# Altium schematic sheet stm32_board.SchDoc(stm32_board)
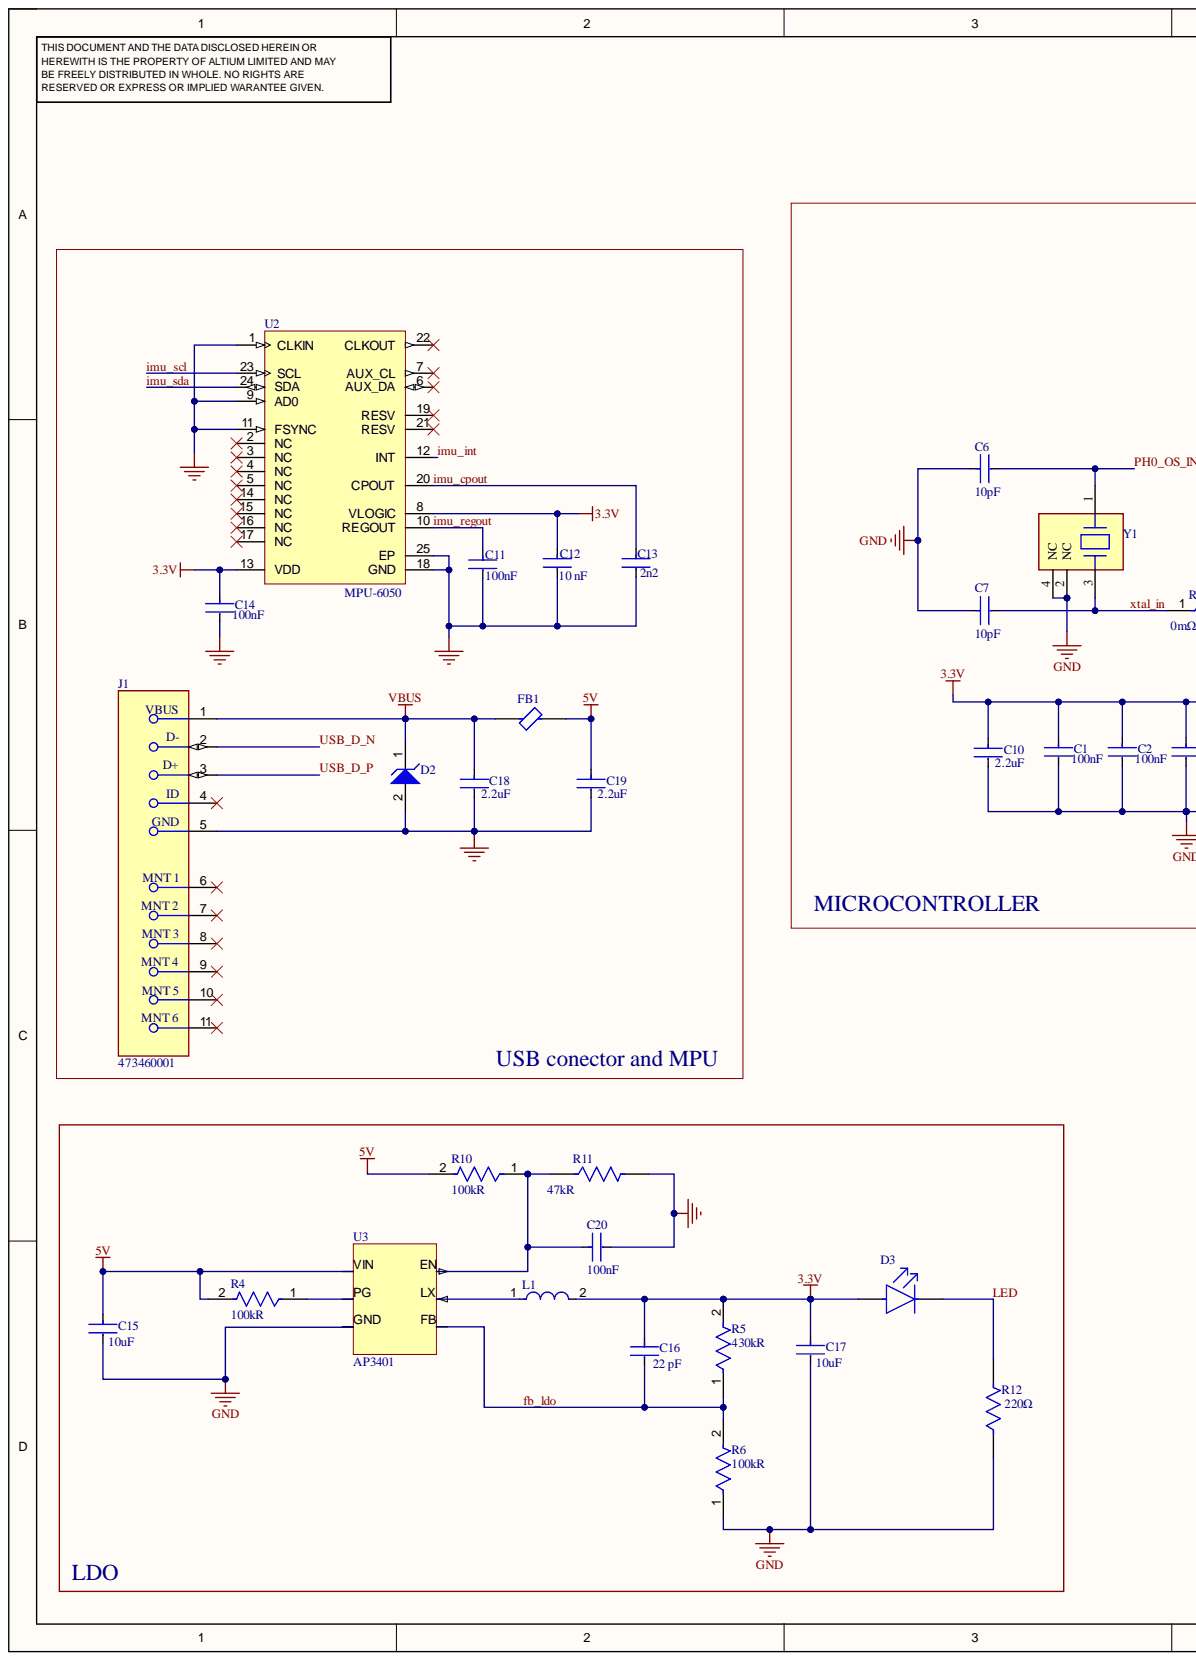
[diagram p0: stm32_board.SchDoc, left]
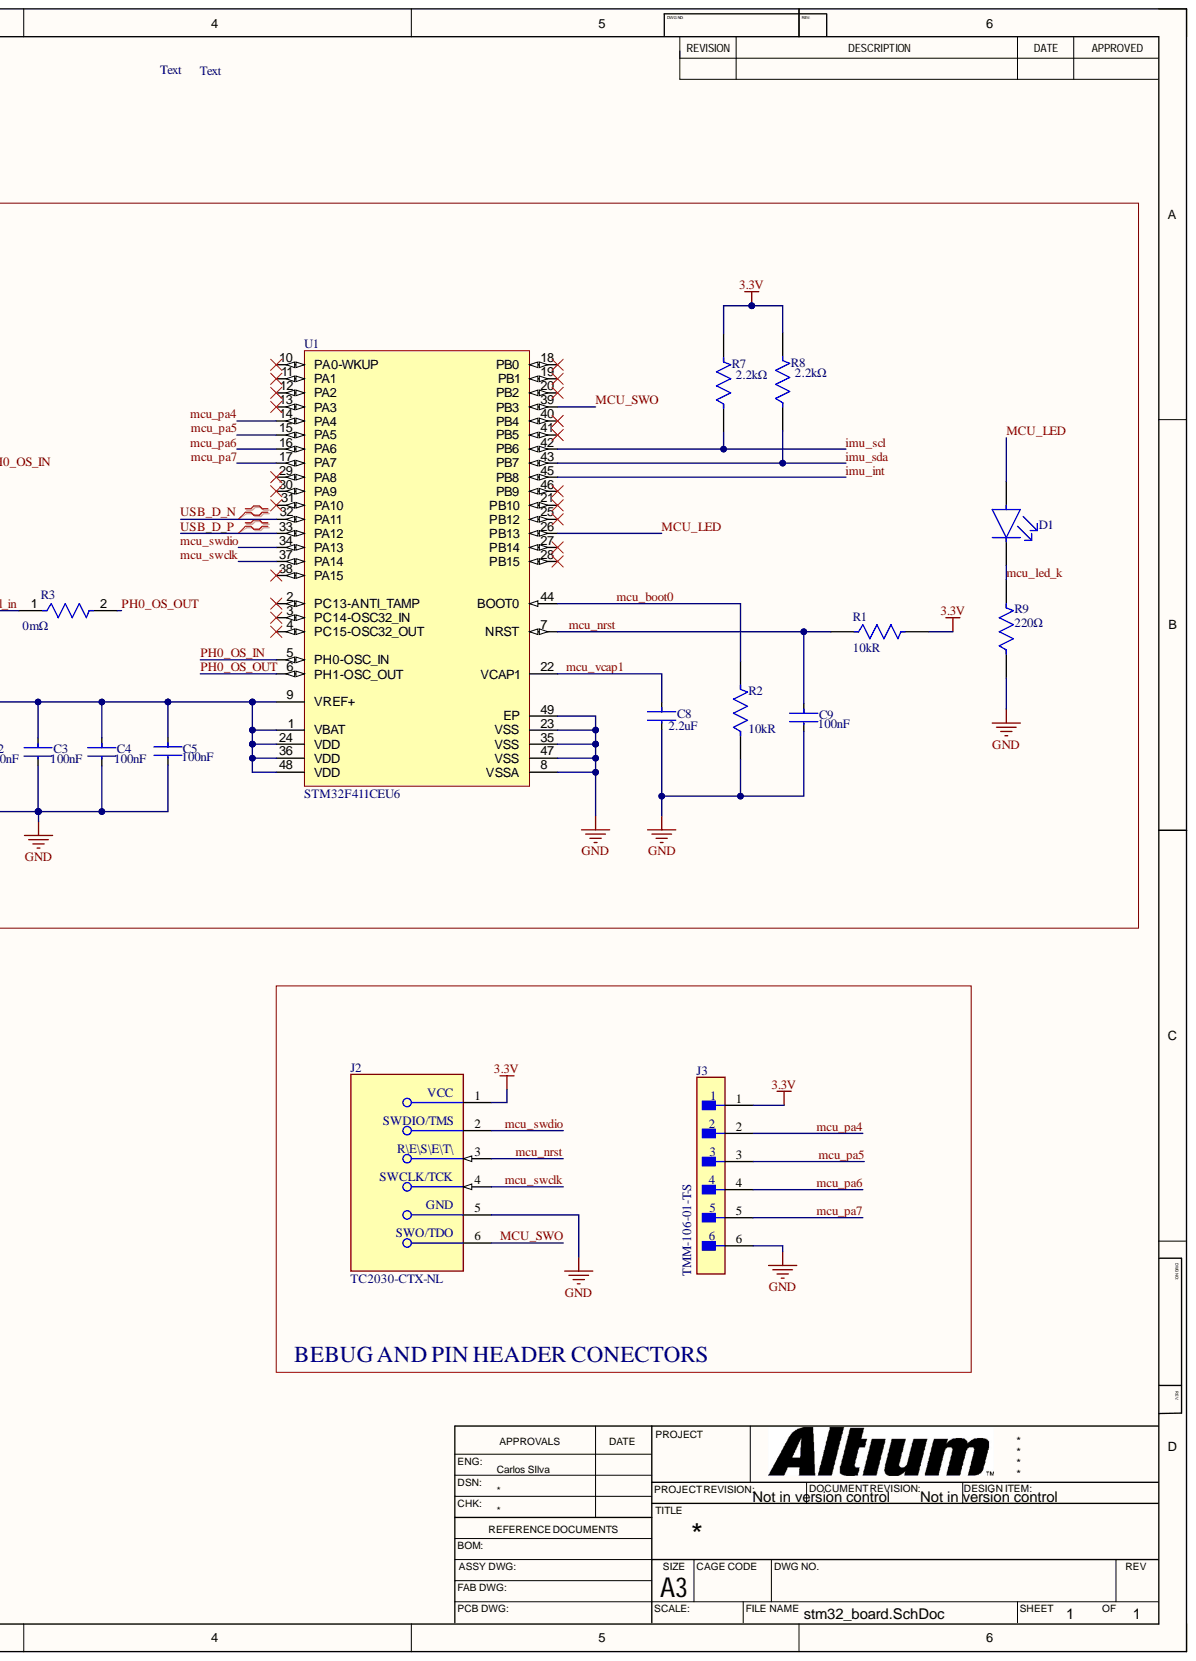
[diagram p1: stm32_board.SchDoc, right]

## Parts
C1  Capacitance: 100nF  [FP-CL17-IPC_C]  (hidden comment: CL05B104KP5NNNC)
C2  Capacitance: 100nF  [FP-CL17-IPC_C]  (hidden comment: CL05B104KP5NNNC)
C3  Capacitance: 100nF  [FP-CL17-IPC_C]  (hidden comment: CL05B104KP5NNNC)
C4  Capacitance: 100nF  [FP-CL17-IPC_C]  (hidden comment: CL05B104KP5NNNC)
C5  Capacitance: 100nF  [FP-CL17-IPC_C]  (hidden comment: CL05B104KP5NNNC)
C6  Capacitance: 10pF  [FP-GRM022-0_02-IPC_C]  (hidden comment: GRM0225C1C100JA03L)
C7  Capacitance: 10pF  [FP-GRM022-0_02-IPC_C]  (hidden comment: GRM0225C1C100JA03L)
C8  Capacitance: 2.2uF  [FP-GRM155-0_2-0_05-IPC_A]  (hidden comment: GRM155D71A225ME15D)
C9  Capacitance: 100nF  [FP-CL17-IPC_C]  (hidden comment: CL05B104KP5NNNC)
C10  Capacitance: 2.2uF  [FP-GRM155-0_2-0_05-IPC_A]  (hidden comment: GRM155D71A225ME15D)
C11  Capacitance: 100nF  [FP-CL17-IPC_C]  (hidden comment: CL05B104KP5NNNC)
C12  Capacitance: 10 nF  [FP-C0603C-CF-MFG]  (hidden comment: C0603C103K5RACTU)
C13  value 2n2  [FP-C0402-BB-MFG]  (hidden comment: C0402C222F4GACTU)
C14  Capacitance: 100nF  [FP-CL17-IPC_C]  (hidden comment: CL05B104KP5NNNC)
C15  Capacitance: 10uF  [FP-0603-L_1_6_0_2-W_0_8_0-IPC_A]  (hidden comment: LMK107BBJ106MALT)
C16  value 22 pF  Capacitance: 22 pF  [FP-C0603C-CF-MFG]  (hidden comment: C0603C220J5GACTU)
C17  Capacitance: 10uF  [FP-0603-L_1_6_0_2-W_0_8_0-IPC_A]  (hidden comment: LMK107BBJ106MALT)
C18  Capacitance: 2.2uF  [FP-GRM155-0_2-0_05-IPC_A]  (hidden comment: GRM155D71A225ME15D)
C19  Capacitance: 2.2uF  [FP-GRM155-0_2-0_05-IPC_A]  (hidden comment: GRM155D71A225ME15D)
C20  Capacitance: 100nF  [FP-CL17-IPC_C]  (hidden comment: CL05B104KP5NNNC)
D1  LTST-C190GKT  [FP-LTST-C190GKT-MFG]  (value not drawn)
D2  ESD9X5.0ST5G  [ONSC-SOD-923-2-514AA-01_V]  (value not drawn)
D3  LTST-C190GKT  [FP-LTST-C190GKT-MFG]  (value not drawn)
FB1  BLM18KG121TN1D  [FP-BLM18-0_15-t0_6-d1_2-IPC_A]  (value not drawn)
J1  473460001  [FP-473460001-MFG]
J2  TC2030-CTX-NL  [FP-TC2030-CTX-NL-MFG]
J3  TMM-106-01-T-S  [FP-TMM-106-01-T-S-MFG]
L1  LQM2MPN2R2NG0L  [FP-LQM2MPN_G0-MFG]  (value not drawn)
R1  Resistance: 10kR  [FP-RMCF0603-MFG]  (hidden comment: RMCF0603FT10K0)
R2  Resistance: 10kR  [FP-RMCF0603-MFG]  (hidden comment: RMCF0603FT10K0)
R3  value 0mΩ  Resistance: 0mΩ  [FP-RMCF0603-MFG]  (hidden comment: RMCF0603ZT0R00)
R4  Resistance: 100kR  [FP-RMCF0603-IPC_C]  (hidden comment: RMCF0603FT100K)
R5  Resistance: 430kR  [FP-KTR25-MFG]  (hidden comment: KTR25JZPF4303)
R6  Resistance: 100kR  [FP-RMCF0603-IPC_C]  (hidden comment: RMCF0603FT100K)
R7  value 2.2kΩ  Resistance: 2.2kΩ  [FP-ERA2A-MFG]  (hidden comment: ERA-2AEB222X)
R8  value 2.2kΩ  Resistance: 2.2kΩ  [FP-ERA2A-MFG]  (hidden comment: ERA-2AEB222X)
R9  value 220Ω  Resistance: 220Ω  [FP-CRGCQ0402-IPC_C]  (hidden comment: CRGCQ0402J220R)
R10  Resistance: 100kR  [FP-RMCF0603-IPC_C]  (hidden comment: RMCF0603FT100K)
R11  Resistance: 47kR  [FP-RC0603FR-0747KL-MFG]  (hidden comment: RC0603FR-0747KL)
R12  value 220Ω  Resistance: 220Ω  [FP-CRGCQ0402-IPC_C]  (hidden comment: CRGCQ0402J220R)
U1  STM32F411CEU6  [STM-UFQFPN48_L]
U2  MPU-6050  [IS-QFN-24_V]
U3  AP3401  [AP3401]
Y1  XRCGB24M000F3M00R0  [FP-XRCGB_F_N-MFG]  (value not drawn)

## Nets
3.3V: C1.1 C2.1 C3.1 C4.1 C5.1 C10.1 C12.1 C14.1 C16.1 C17.1 D3.2 J2.1 J3.1 L1.2 R1.2 R5.2 R7.2 R8.2 U1.1(VBAT) U1.9(VREF+) U1.24(VDD) U1.36(VDD) U1.48(VDD) U2.8(VLOGIC) U2.13(VDD)
5V: C15.1 C19.1 FB1.2 R4.2 R10.2 U3.VIN
GND: C1.2 C2.2 C3.2 C4.2 C5.2 C6.1 C7.1 C8.2 C9.2 C10.2 C11.2 C12.2 C13.2 C14.2 C15.2 C17.2 C18.2 C19.2 C20.2 D2.2 J1.5 J2.5 J3.6 R2.1 R6.1 R9.1 R11.2 R12.1 U1.8(VSSA) U1.23(VSS) U1.35(VSS) U1.47(VSS) U1.49(EP) U2.1(CLKIN) U2.9(AD0) U2.11(FSYNC) U2.18(GND) U2.25(EP) U3.GND Y1.2(NC) Y1.4(NC)
VBUS: C18.1 D2.1 FB1.1 J1.1
fb_ldo: C16.2 R5.1 R6.2 U3.FB
imu_cpout: C13.1 U2.20(CPOUT)
imu_int: U1.45(PB8) U2.12(INT)
imu_regout: C11.1 U2.10(REGOUT)
imu_scl: R7.1 U1.42(PB6) U2.23(SCL)
imu_sda: R8.1 U1.43(PB7) U2.24(SDA)
LED: D3.1 R12.2
mcu_boot0: R2.2 U1.44(BOOT0)
MCU_LED: D1.2 U1.26(PB13)
mcu_led_k: D1.1 R9.2
mcu_nrst: C9.1 J2.3 R1.1 U1.7(NRST)
mcu_pa4: J3.2 U1.14(PA4)
mcu_pa5: J3.3 U1.15(PA5)
mcu_pa6: J3.4 U1.16(PA6)
mcu_pa7: J3.5 U1.17(PA7)
mcu_swclk: J2.4 U1.37(PA14)
mcu_swdio: J2.2 U1.34(PA13)
MCU_SWO: J2.6 U1.39(PB3)
mcu_vcap1: C8.1 U1.22(VCAP1)
PH0_OS_IN: C6.2 U1.5(PH0-OSC_IN) Y1.1
PH0_OS_OUT: R3.2 U1.6(PH1-OSC_OUT)
USB_D_N: J1.2 U1.32(PA11)
USB_D_P: J1.3 U1.33(PA12)
xtal_in: C7.2 R3.1 Y1.3
NetC20_1: C20.1 R10.1 R11.1 U3.EN
NetL1_1: L1.1 U3.LX
NetR4_1: R4.1 U3.PG

Not connected: J1 4 6 7 8 9 10 11; U1 2(PC13-ANTI_TAMP) 3(PC14-OSC32_IN) 4(PC15-OSC32_OUT) 10(PA0-WKUP) 11(PA1) 12(PA2) 13(PA3) 18(PB0) 19(PB1) 20(PB2) 21(PB10) 25(PB12) 27(PB14) 28(PB15) 29(PA8) 30(PA9) 31(PA10) 38(PA15) 40(PB4) 41(PB5) 46(PB9); U2 2(NC) 3(NC) 4(NC) 5(NC) 6(AUX_DA) 7(AUX_CL) 14(NC) 15(NC) 16(NC) 17(NC) 19(RESV) 21(RESV) 22(CLKOUT)

## Library data of the parts
C1-C5 C9 C11 C14 C20 = CMP-13271-001069-1: Cap Ceramic 100nF 10V X7R ±10% SMD 0402 +125°C Paper T/R; Case Code (Imperial): 0402; Case Code (Metric): 1005; Case/Package: 0402; Component Kind: Standard; Contact Plating: Tin; Depth: 0.5mm; Dielectric: X7R; Height: 0.5mm; Length: 1mm; Library Name: Altium Content Vault; Max Operating Temperature: 125°C; Min Operating Temperature: -55°C; Mount: Surface Mount; Package Quantity: 1; Packaging: Tape and Reel; Radiation Hardening: No; Thickness: 0.022inch; Tolerance: 10%; Voltage Rating: 10V; Width: 0.02inch
C6 C7 = CMP-06035-032745-1: Chip Multilayer Ceramic Capacitors for General Purpose, 01005, 10pF, C0G, 30ppm/°C, 5%, 16V; Case Code (Imperial): 1005; Case Code (Metric): 0402; Component Kind: Standard; Dielectric: C0G; Length: 0.016inch; Library Name: Altium Content Vault; Max Operating Temperature: 125°C; Min Operating Temperature: -55°C; Mount: Surface Mount; Packaging: Cut Tape; Thickness: 0.009inch; Tolerance: 5%; Voltage Rating: 16V; Voltage Rating (DC): 16V; Width: 0.008inch
C8 C10 C18 C19 = CMP-06035-053899-1: Chip Multilayer Ceramic Capacitors for General Purpose, 0402, 2.2uF, X7T, +22%/-33%, 20%, 10V; Case Code (Imperial): 0402; Case Code (Metric): 1005; Case/Package: 0402; Component Kind: Standard; Dielectric: X7T; Height: 0.5mm; Length: 0.039inch; Library Name: Altium Content Vault; Max Operating Temperature: 125°C; Min Operating Temperature: -55°C; Mount: Surface Mount; Package Quantity: 10000; Packaging: Tape and Reel; Termination: SMD/SMT; Thickness: 0.022inch; Tolerance: 20%; Voltage Rating: 10V; Voltage Rating (DC): 10V; Weight: 0.000212oz; Width: 0.02inch
C12 = CMP-2006-03046-3: CAP CER 10000PF 50V X7R 0603; Manufacturer: KEMET; Automotive Grade: Grade 1; Material: Ceramic; Temperature Coefficient: 30ppm/°C; Tolerance: 0.1; Value: 10,000 pF; Automotive: Yes; Category: Cap; Component Kind: Standard; ComponentLink2Description: Datasheet; ComponentLink2URL: https://api.kemet.com/component-edge/download/datasheet/C0603C100J5GACAUTO.pdf; Device Class L1: Passive Components; Device Class L2: Capacitors; Device Class L3: Ceramic Capacitors; Digikey Description: CAP CER 10000PF 50V X7R 0603; Height: 0.87mm; Lead Free: Yes; Library Name: Altium Content Vault; Manufacturer Part Number: C0603C103K5RACTU; Mouser Description: Ceramic Capacitor 0.01Uf, 50V, X7R, 10%, 0603, Full Reel; Octopart: https://octopart.com/c0603c103k5ractu-kemet-133094; Package: C0603C-CF; RoHS: TRUE; Temperature: -55°C to +125°C; Temperature Characteristic: X7R; Temperature Range High: +125°C; Temperature Range Low: -55°C; Voltage Rating: 50 V
C13 = CMP-1034-01730-4: CAP CER 2200PF 16V NP0 0402; Manufacturer: Kemet; Capacitance: 2,200 pF; Material: Ceramic; Temperature Characteristic: C0G; Temperature Coefficient: 15%; Tolerance: 0.01; Automotive: No; Category: Cap; Component Kind: Standard; ComponentLink2Description: Datasheet; ComponentLink2URL: https://content.kemet.com/datasheets/KEM_C1002_X7R_SMD.pdf; Device Class L1: Passive Components; Device Class L2: Capacitors; Device Class L3: Ceramic Capacitors; Digikey Description: CAP CER 2200PF 16V NP0 0402; Height: 0.55mm; Ipc Land Pattern Name: CAPC10050X50; Lead Free: Yes; Library Name: Altium Content Vault; Manufacturer Part Number: C0402C222F4GACTU; Mouser Description: Cap Ceramic 0.0022uF 16V C0G 1% SMD 0402 125°C Punched Paper T/R; Octopart: https://octopart.com/c0402c222f4gactu-kemet-12823119; Package: C0402-BB; RoHS: TRUE; Temperature: -55°C to +125°C; Temperature Range High: +125°C; Temperature Range Low: -55°C; Voltage Rating: 16 V
C15 C17 = CMP-14477-000150-3: Multilayer Ceramic Capacitor 10uF 10V X5R 20% SMD 0603 T/R; Case Code (Imperial): 0603; Case Code (Metric): 1608; Case/Package: 0603; Component Kind: Standard; Construction: Flat; Depth: 0.8mm; Dielectric: X5R; Height: 0.8mm; Lead Free: Lead Free; Length: 1.6mm; Library Name: Altium Content Vault; Max Operating Temperature: 85°C; Min Operating Temperature: -55°C; Mount: Surface Mount; Package Quantity: 1; Packaging: Tape and Reel; Radiation Hardening: No; Resistor Type: Ceramic; RoHS Compliant: Yes; Series: M; Termination: SMD/SMT; Thickness: 0.035inch; Tolerance: 20%; Voltage: 10V; Voltage Rating: 10V; Voltage Rating (DC): 10V; Width: 0.8mm
C16 = CMP-2006-03119-3: Cap Ceramic 22pF 50V C0G 5% SMD 0603 125°C Paper T/R; Manufacturer: KEMET; Automotive Grade: Grade 1; Temperature Coefficient: 30ppm/°C; Tolerance: 0.05; Automotive: Yes; Category: Cap; Component Kind: Standard; ComponentLink2Description: Datasheet; ComponentLink2URL: https://api.kemet.com/component-edge/download/datasheet/C0603C100J5GACAUTO.pdf; Device Class L1: Passive Components; Device Class L2: Capacitors; Device Class L3: Ceramic Capacitors; Digikey Description: Cap Ceramic 22pF 50V C0G 5% SMD 0603 125°C Paper T/R; Height: 0.87mm; Lead Free: Yes; Library Name: Altium Content Vault; Manufacturer Part Number: C0603C220J5GACTU; Material: Ceramic; Mouser Description: Cap Ceramic 22pF 50V C0G 5% SMD 0603 125°C Paper T/R; Octopart: https://octopart.com/c0603c220j5gactu-kemet-98525; Package: C0603C-CF; RoHS: TRUE; Temperature: -55°C to +125°C; Temperature Characteristic: C0G; Temperature Range High: +125°C; Temperature Range Low: -55°C; Voltage Rating: 50 V
D1 D3 = CMP-22017-000005-2: LED GREEN CLEAR CHIP SMD; Dominant Wavelength: 569nm; Forward Current: 30mA; Forward Voltage: 2.1V; Lens Color: Colorless; Luminous Intensity: 6mcd; Number of Elements: 1; Power Dissipation: 100mW; Reverse Voltage: 5V; Test Current: 10mA; Viewing Angle: 130°; Voltage: 2V; Wavelength: 565nm; Case Code (Imperial): 0603; Case Code (Metric): 1608; Case/Package: 0603; Color: Green; Component Kind: Standard; Depth: 0.8mm; Height: 0.8mm; Illumination Color: Green; Lead Free: Lead Free; Length: 1.6mm; Lens Style: Clear; Lens Transparency: Clear; Library Name: Altium Content Vault; Max Operating Temperature: 85°C; Min Operating Temperature: -55°C; Mount: Surface Mount; Number of LEDs: 1; Number of Pins: 2; Package Quantity: 1; Packaging: Tape and Reel; Power Rating: 100mW; Radiation Hardening: No; Width: 0.8mm
D2 = CMP-1063-00108-1: Transient Voltage Suppressor, Micro-Packaged Diode for ESD Protection, 2-Pin SOD-923, Pb-Free, Tape and Reel; Manufacturer: On Semiconductor; Direction: Unidirectional; IR Max (uA): 1; V(BR) Min (V): 6.2; VRWM Max (V): 5; Component Kind: Standard; ComponentLink1Description: Manufacturer URL; ComponentLink1URL: http://www.onsemi.com/; ComponentLink2Description: Datasheet; ComponentLink2URL: http://www.onsemi.cn/pub_link/Collateral/ESD9X3.3ST5G-D.PDF; ComponentLink3Description: Package Specification; ComponentLink3URL: http://www.onsemi.com/pub_link/Collateral/514AA.PDF; DatasheetVersion: Rev. 7; Library Name: Altium Content Vault; Mounting Technology: Surface Mount; Number of Lines: 1; PackageDescription: 2-Pin SOD, Body 0.8 x 0.6 mm; PackageReference: SOD-923-2-514AA-01; PackageVersion: Rev. E, 03/2011; Packing: Tape and Reel; PartNumber: ESD9X5.0ST5G; RoHS: Pb-Free
FB1 = CMP-0686-00554-8: FERRITE BEAD 120 OHM 0603 1LN; Current Rating: 3A; DC Resistance (DCR): 30mR; Impedance: 120R; Number of Circuits: 1; Test Frequency: 100MHz; Tolerance: 25%; Case Code (Imperial): 0603; Case Code (Metric): 1608; Case/Package: 0603; Component Kind: Standard; Core Material: Ferrite; Depth: 0.95mm; Height: 0.8mm; Inductor Type: FERRITE BEAD; Lead Free: Lead Free; Length: 1.6mm; Library Name: Altium Content Vault; Material: Ferrite; Max Current Rating: 3A; Max DC Current: 3A; Max Operating Temperature: 125°C; Min Operating Temperature: -55°C; Number of Lines: 1; Number of Pins: 2; Package Quantity: 4000; Packaging: Tape and Reel; REACH SVHC: No SVHC; RoHS Compliant: Yes; Series: BLM18K; Termination: SMD/SMT; Width: 0.8mm
J1 = CMP-2000-05246-2: CONN RCPT USB2.0 MICRO B SMD R/A; Manufacturer: Molex; Conn Orientation: Right Angle; Current Rating: 1.8A; Is Female: yes; Number Of Contacts: 5; Pitch: 0.65mm; Shielding: true; Voltage Rating: 30V; Automotive: No; Category: Conn; Component Kind: Standard; ComponentLink2Description: Datasheet; ComponentLink2URL: https://www.molex.com/webdocs/datasheets/pdf/en-us/0473460001_IO_CONNECTORS.pdf; Contact Material: Gold,Nickel; Device Class L1: Connectors; Device Class L2: USB Connectors; Device Class L3: unset; Digikey Description: CONN RCPT USB2.0 MICRO B SMD R/A; Footprint Url: https://www.molex.com/pdm_docs/sd/473460001_sd.pdf; Height: 2.945mm; Lead Free: Yes; Library Name: Altium Content Vault; Manufacturer Part Number: 473460001; Octopart: https://octopart.com/47346-0001-molex-7164928; Package: 0; RoHS: TRUE; Temperature: -30°C to +85°C; Temperature Range High: +85°C; Temperature Range Low: -30°C; Usb Standard: USB 2.0
J2 = CMP-205963-000001-1: 6-Pin “No legs” TC2030 Plug-of-Nails™ Cable for use with ARM Cortex processors; Component Kind: Standard; Library Name: Altium Content Vault
J3 = CMP-02766-001694-1: CONN HEADER VERT 6POS 2MM; Connector Type: Male Pin; Gender: Male; Max Voltage Rating (AC): 500V; Number of Contacts: 6; Color: Black; Component Kind: Standard; Contact Material: Bronze; Contact Mating Length: 0.126inch; Contact Plating: Tin; Contact Type: Male Pin; Depth: 1.97mm; Housing Color: Black; Housing Material: Polymer; Lead Free: Lead Free; Length: 12mm; Library Name: Altium Content Vault; Max Operating Temperature: 105°C; Min Operating Temperature: -55°C; Mount: Through Hole; Number of Positions: 6; Number of Rows: 1; Orientation: Straight; Packaging: Bulk; Pitch: 2mm; Radiation Hardening: No; REACH SVHC: No SVHC; RoHS Compliant: Yes; Series: FleXYZ™ TMM; Termination: Solder; Width: 1.97mm
L1 = CMP-06042-016770-1: Multilayer Type Inductor for Power Lines, 0806 (2016), 2.2μH, 30%, 0.138Ω, 1.2A; Core Material: Ferrite; DC Resistance (DCR): 138mR; Inductance: 2.2uH; Self Resonant Frequency: 40MHz; Shielding: Shielded; Test Frequency: 1MHz; Case Code (Imperial): 0806; Case Code (Metric): 2016; Case/Package: 0806; Component Kind: Standard; Current Rating: 1.2A; DC Current: 1.2A; Depth: 1.75mm; Height: 1mm; Height - Seated (Max): 0.039inch; Inductance Tolerance: 30%; Lead Free: Lead Free; Length: 0.079inch; Library Name: Altium Content Vault; Max DC Current: 1.2A; Max Operating Temperature: 125°C; Military Standard: Not; Min Operating Temperature: -55°C; Mount: Surface Mount; Package Quantity: 1; Packaging: Tape and Reel; Radiation Hardening: No; REACH SVHC: No SVHC; RoHS Compliant: Yes; Series: LQM2MPN_G0; Termination: SMD/SMT; Tolerance: 30%; Width: 0.063inch
R1 R2 = CMP-2000-06990-2: 10kΩ ±1% 0.1W 0603 Thick Film Chip Resistor AEC-Q200 compliant; Max Power Dissipation: 100mW; Temperature Coefficient: 100ppm/°C; Case Code (Imperial): 0603; Case Code (Metric): 1608; Case/Package: 0603; Component Kind: Standard; Construction: Rectangular; Contact Plating: Tin; Depth: 0.8mm; Features: Automotive AEC-Q200; Height: 0.022inch; Lead Free: Lead Free; Length: 1.55mm; Library Name: Altium Content Vault; Max Operating Temperature: 155°C; Military Standard: Not; Min Operating Temperature: -55°C; Mount: Surface Mount; Number of Terminations: 2; Packaging: Tape and Reel; Power Rating: 100mW; Radiation Hardening: No; Resistor Type: Thick Film; RoHS Compliant: Yes; Series: RMCF; Size Code: 0603; Tolerance: 1%; Width: 0.031inch
R3 = CMP-26527-000011-1: RES 0 OHM JUMPER 1/10W 0603; Manufacturer: Stackpole; Automotive Grade: Grade 0; Power Rating: 0.1W; Voltage Rating: 75V; Automotive: Yes; Category: Res; Component Kind: Standard; ComponentLink2Description: Datasheet; ComponentLink2URL: https://www.seielect.com/catalog/sei-rmcf_rmcp.pdf; Composition: Thick Film; Device Class L1: Passive Components; Device Class L2: Resistors; Device Class L3: Chip SMD Resistors; Digikey Description: RES 0 OHM JUMPER 1/10W 0603; Height: 0.55mm; Ipc Land Pattern Name: RESC15580X45; Lead Free: Yes; Library Name: Altium Content Vault; Manufacturer Part Number: RMCF0603ZT0R00; Octopart: https://octopart.com/rmcf0603zt0r00-stackpole+electronics-19213618; Package: RMCF0603; RoHS: TRUE; Series: RMCF; Temperature: -55°C to +155°C; Temperature Range High: +155°C; Temperature Range Low: -55°C
R4 R6 R10 = CMP-2000-06989-2: 100kΩ ±1% 0.1W 0603 Thick Film Chip Resistor AEC-Q200 compliant; Max Power Dissipation: 100mW; Temperature Coefficient: 100ppm/°C; Working Voltage: 75V; Case Code (Imperial): 0603; Case Code (Metric): 1608; Case/Package: 0603; Component Kind: Standard; Construction: Rectangular; Contact Plating: Tin; Depth: 0.8mm; Features: Automotive AEC-Q200; Height: 0.022inch; Lead Free: Lead Free; Length: 1.55mm; Library Name: Altium Content Vault; Max Operating Temperature: 155°C; Military Standard: Not; Min Operating Temperature: -55°C; Mount: Surface Mount; Number of Terminations: 2; Packaging: Tape and Reel; Power Rating: 100mW; Radiation Hardening: No; Resistor Type: Thick Film; RoHS Compliant: Yes; Series: RMCF; Tolerance: 1%; Width: 0.031inch
R5 = CMP-08839-003750-1: Res Thick Film 1210 430kΩ 1% 0.1W ±100ppm/°C Automotive Embossed T/R; Max Power Dissipation: 333mW; Case Code (Imperial): 1210; Case Code (Metric): 3225; Case/Package: 1210; Component Kind: Standard; Features: Automotive AEC-Q200; Height: 0.028inch; Length: 0.126inch; Library Name: Altium Content Vault; Max Operating Temperature: 155°C; Min Operating Temperature: -55°C; Number of Terminations: 2; Package Quantity: 4000; Packaging: Tape and Reel; Resistor Type: Thick Film; RoHS Compliant: Yes; Series: KTR; Temperature Coefficient: 100ppm/°C; Tolerance: 1%; Width: 0.098inch
R7 R8 = CMP-2002-05881-2: RES SMD 2.2K OHM 0.1% 1/16W 0402; Manufacturer: Panasonic; Automotive Grade: Grade 0; Power Rating: 0.063W; Temperature Coefficient: 25ppm/°C; Voltage Rating: 50V; Automotive: Yes; Category: Res; Component Kind: Standard; ComponentLink2Description: Datasheet; ComponentLink2URL: https://industrial.panasonic.com/cdbs/www-data/pdf/RDM0000/AOA0000C307.pdf; Composition: Metal Film; Device Class L1: Passive Components; Device Class L2: Resistors; Device Class L3: Chip SMD Resistors; Digikey Description: RES SMD 2.2K OHM 0.1% 1/16W 0402; Footprint Url: https://b2b-api.panasonic.eu/file_stream/pids/fileversion/1079; Height: 0.4mm; Ipc Land Pattern Name: RESC10050X35; Lead Free: Yes; Library Name: Altium Content Vault; Manufacturer Part Number: ERA-2AEB222X; Mouser Description: Thin Film Resistors - SMD 0402 1/16W 2.2Kohms; Octopart: https://octopart.com/era-2aeb222x-panasonic-9251391; Package: ERA2A; RoHS: TRUE; Series: E24; Temperature: -55°C to +155°C; Temperature Range High: +155°C; Temperature Range Low: -55°C; Tolerance: 0.1%
R9 R12 = CMP-03211-000793-1: CRGCQ 0402 220R 5%; Manufacturer: TE Connectivity; Power Rating: 0.0625W; Temperature Coefficient: ±100ppm/°C; Tolerance: 0.05; Voltage Rating: 50V; Ambient Temperature Range High: +70°C; Automotive: Yes; Category: Res; Component Kind: Standard; ComponentLink2Description: Datasheet; ComponentLink2URL: https://www.te.com/commerce/DocumentDelivery/DDEController?Action=showdoc&DocId=Data+Sheet%7F1773204-3%7F1%7Fpdf%7FEnglish%7FENG_DS_1773204-3_1.pdf%7F4-2176347-539; Composition: Thick Film; Device Class L1: Passive Components; Device Class L2: Resistors; Device Class L3: Chip SMD Resistors; Digikey Description: CRGCQ 0402 220R 5%; Height: 0.4mm; Ipc Land Pattern Name: RESC10050X35; Lead Free: Yes; Library Name: Altium Content Vault; Manufacturer Part Number: CRGCQ0402J220R; Octopart: https://octopart.com/crgcq0402j220r-te+connectivity-91018007; Package: CRGCQ0402; RoHS: TRUE; Series: CRGCQ; Temperature: -55°C to +155°C; Temperature Range High: +155°C; Temperature Range Low: -55°C
R11 = CMP-1659-00055-2: RES SMD 47K OHM 1% 1/10W 0603; Max Power Dissipation: 100mW; Temperature Coefficient: 100ppm/°C; Voltage Rating: 50V; Case Code (Imperial): 0603; Case Code (Metric): 1608; Case/Package: 0603; Component Kind: Standard; Construction: Rectangular; Depth: 0.8mm; Features: Moisture Resistant; Height: 0.022inch; Lead Free: Lead Free; Length: 0.063inch; Library Name: Altium Content Vault; Max Operating Temperature: 155°C; Military Standard: Not; Min Operating Temperature: -55°C; Number of Pins: 2; Number of Terminations: 2; Package Quantity: 1; Packaging: Tape and Reel; Power Rating: 100mW; Resistance Tolerance: 1%; Resistor Type: Thick Film; RoHS Compliant: Yes; Series: RC; Size Code: 0603; Technology: THICK FILM; Termination: SMD/SMT; Tolerance: 1%; Voltage Rating (DC): 50V; Width: 0.031inch
U1 = CMP-0243-00165-1: ARM Cortex-M4 32-bit MCU+FPU, 512 KB Flash, 128 KB RAM, 36 I/Os, 48-Pin UFQFPN, -40 to 85 degC, Tray; FLASH Size (Prog) (kB): 512; I/Os (High Current): 36; Internal RAM Size (kB): 128; Operating Frequency(F) (Processor speed) (MHz): 100; Supply Voltage(Vcc) min (V): 1.7; Component Kind: Standard; ComponentLink1Description: Manufacturer URL; ComponentLink1URL: http://www.st.com/; ComponentLink2Description: Datasheet; ComponentLink2URL: http://www.st.com/st-web-ui/static/active/en/resource/technical/document/datasheet/DM00115249.pdf; Core: ARM Cortex-M4; DatasheetVersion: Rev. 6; Library Name: Altium Content Vault; Mounting Technology: Surface Mount; Package Description: UFQFPN48; Package Reference: 48-Pin Ultra Thin Fine Pitch Quad Flat No-Lead, 7 x 7 x 0.6 mm Body, 0.5 mm Pitch, Exposed Pad; Packing: Tray
U2 = CMP-1753-00002-2: 3-Axis Gyroscope, Accelerometer and a Digital Motion Processor(TM) (DMP), 2.375 to 3.46 V, -40 to 85 degC, 24-Pin QFN, RoHS, Tape and Reel; Manufacturer: InvenSense; Dynamic Catalog: MPU-6000™Series; Featured Product: MPU-60506-Axis,IntegratedI²CSolution; Other Names: 1428-1007-2  MPU6050; Sensor Type: MotionTracking; Category: Sensors,Transducers; Component Kind: Standard; Datasheet URL: http://datasheet.octopart.com/MPU-6050-InvenSense-datasheet-14421344.pdf; Datasheet Version: Rev. 3.3, 05/2012; Family: Multifunction; Library Name: Altium Content Vault; Manufacturer URL: http://www.invensense.com/; Online Catalog: MPU-6000™Series; Output Type: Digital; Standard Package: 5,000; Unit PriceUSD: Digital
U3 = AP3401: 1.5MHZ, 1A STEP-DOWN DC - DC BUCK CONVERTER; Component Kind: Standard; ComponentLink1Description: Datasheet; ComponentLink1URL: https://www.diodes.com/assets/Datasheets/AP3401.pdf; Library Name: Mi_Libreria.IntLib
Y1 = CMP-06054-000017-1: CRYSTAL 24.0000MHZ 6PF SMD; ESR (Equivalent Series Resistance): 150R; Frequency: 24MHz; Frequency Stability: 40ppm; Frequency Tolerance: 30ppm; Operating Frequency: 24 MHz; Case/Package: SMD/SMT; Component Kind: Standard; Depth: 1.6mm; Height: 0.028inch; Lead Free: Lead Free; Length: 2mm; Library Name: Altium Content Vault; Load Capacitance: 6pF; Max Operating Temperature: 85°C; Min Operating Temperature: -30°C; Mount: Surface Mount; Number of Pins: 4; Package Quantity: 1; Packaging: Tape and Reel; REACH SVHC: No SVHC; RoHS Compliant: Yes; Series: XRCGB; Series Resistance: 150R; Termination: SMD/SMT; Tolerance: 30ppm; Width: 1.6mm
